# Altium schematic sheet COLLAUDO1.SchDoc
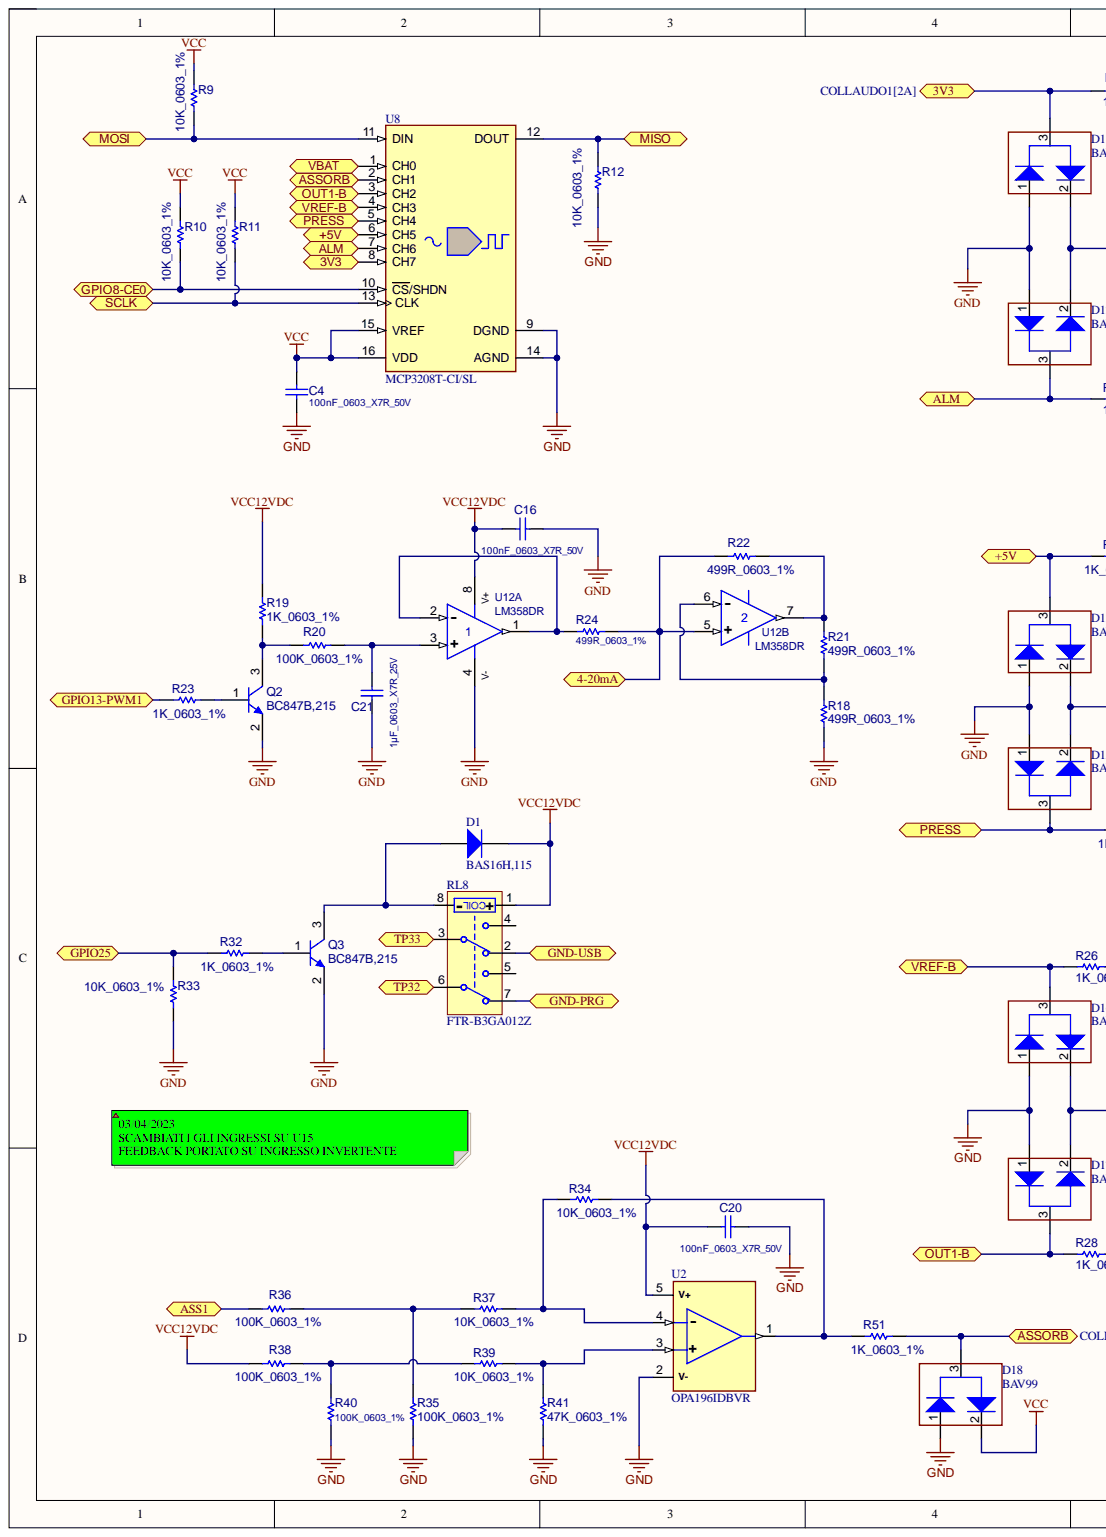
[diagram p0: COLLAUDO1.SchDoc, left]
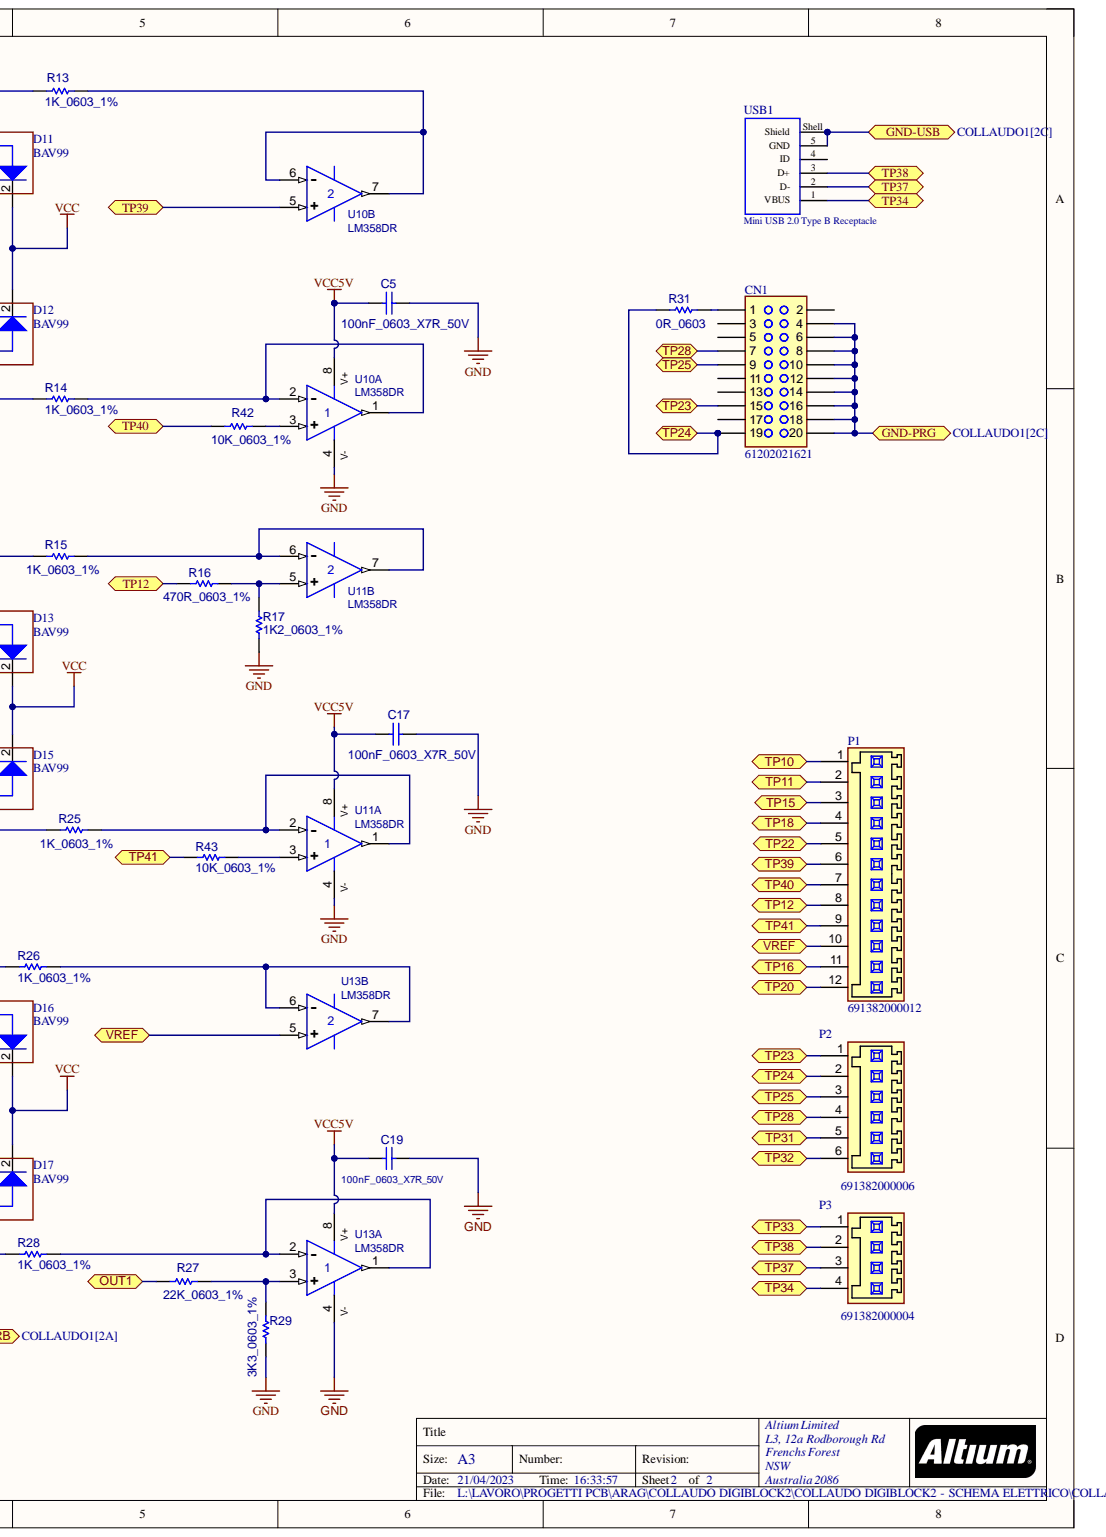
[diagram p1: COLLAUDO1.SchDoc, right]

## Parts
C4  100nF_0603_X7R_50V  [C_0603]
C5  100nF_0603_X7R_50V  [C_0603]
C16  100nF_0603_X7R_50V  [C_0603]
C17  100nF_0603_X7R_50V  [C_0603]
C19  100nF_0603_X7R_50V  [C_0603]
C20  100nF_0603_X7R_50V  [C_0603]
C21  1µF_0603_X7R_25V  [C_0603]
CN1  61202021621  [CONNETTORE_WE_61202021621]
D1  BAS16H,115  [SOD_123FL]
D11  BAV99  [SOT-23 3PIN]
D12  BAV99  [SOT-23 3PIN]
D13  BAV99  [SOT-23 3PIN]
D15  BAV99  [SOT-23 3PIN]
D16  BAV99  [SOT-23 3PIN]
D17  BAV99  [SOT-23 3PIN]
D18  BAV99  [SOT-23 3PIN]
P1  691382000012  [CONNETTORE_WE_691382000012]
P2  691382000006  [CONNETTORE_WE_691382000006]
P3  691382000004  [CONNETTORE_WE_691382000004]
Q2  BC847B,215  [SOT-23 3PIN]
Q3  BC847B,215  [SOT-23 3PIN]
R9  10K_0603_1%  [R_0603]
R10  10K_0603_1%  [R_0603]
R11  10K_0603_1%  [R_0603]
R12  10K_0603_1%  [R_0603]
R13  1K_0603_1%  [R_0603]
R14  1K_0603_1%  [R_0603]
R15  1K_0603_1%  [R_0603]
R16  470R_0603_1%  [R_0603]
R17  1K2_0603_1%  [R_0603]
R18  499R_0603_1%  [R_0603]
R19  1K_0603_1%  [R_0603]
R20  100K_0603_1%  [R_0603]
R21  499R_0603_1%  [R_0603]
R22  499R_0603_1%  [R_0603]
R23  1K_0603_1%  [R_0603]
R24  499R_0603_1%  [R_0603]
R25  1K_0603_1%  [R_0603]
R26  1K_0603_1%  [R_0603]
R27  22K_0603_1%  [R_0603]
R28  1K_0603_1%  [R_0603]
R29  3K3_0603_1%  [R_0603]
R31  0R_0603  [R_0603]
R32  1K_0603_1%  [R_0603]
R33  10K_0603_1%  [R_0603]
R34  10K_0603_1%  [R_0603]
R35  100K_0603_1%  [R_0603]
R36  100K_0603_1%  [R_0603]
R37  10K_0603_1%  [R_0603]
R38  100K_0603_1%  [R_0603]
R39  10K_0603_1%  [R_0603]
R40  100K_0603_1%  [R_0603]
R41  47K_0603_1%  [R_0603]
R42  10K_0603_1%  [R_0603]
R43  10K_0603_1%  [R_0603]
R51  1K_0603_1%  [R_0603]
RL8  FTR-B3GA012Z  [RELE' FUJITSU FTR-B3S]
U2  OPA196IDBVR  [SOT23_5PIN_TI]
U8  MCP3208T-CI/SL  [SOT23_5PIN]
U10  LM358DR  [SOP_8]  (parts A,B)
U11  LM358DR  [SOP_8]  (parts A,B)
U12  LM358DR  [SOP_8]  (parts A,B)
U13  LM358DR  [SOP_8]  (parts A,B)
USB1  Mini USB 2.0 Type B Receptacle  Application: Mini USB 2.0  Gender: Receptacle  Interface Type: Type B  [614105150721]

## Ports
3V3, 4-20mA, +5V, ALM, ASS1, ASSORB, GND-PRG, GND-USB, GPIO8-CE0, GPIO13-PWM1, GPIO25, MISO, MOSI, OUT1, OUT1-B, PRESS, SCLK, TP10, TP11, TP12, TP15, TP16, TP18, TP20, TP22, TP23, TP24, TP25, TP28, TP31, TP32, TP33, TP34, TP37, TP38, TP39, TP40, TP41, VBAT, VREF, VREF-B

## Nets
GND: C4.1 C5.2 C16.2 C17.2 C19.2 C20.2 C21.1 D11.1 D12.1 D13.1 D15.1 D16.1 D17.1 D18.1 Q2.2 Q3.2 R12.2 R17.2 R18.1 R29.1 R33.2 R35.1 R40.1 R41.2 U2.2(V-) U8.9(DGND) U8.14(AGND) U10.4 U11.4 U12.4 U13.4
VCC: C4.2 D11.2 D12.2 D13.2 D15.2 D16.2 D17.2 D18.2 R9.1 R10.1 R11.1 U8.15(VREF) U8.16(VDD)
VCC5V: C5.1 C17.1 C19.1 U10.8 U11.8 U13.8
VCC12VDC: C16.1 C20.1 D1.2 R19.2 R38.1 RL8.1(+) U2.5(V+) U12.8
3V3: D11.3 R13.1 U8.8(CH7) ; port 3V3
4-20mA: R22.1 R24.1 U12.5(+) ; port 4-20mA
+5V: D13.3 R15.1 U8.6(CH5) ; port +5V
ALM: D12.3 R14.1 U8.7(CH6) ; port ALM
ASS1: R36.1 ; port ASS1
ASSORB: D18.3 R51.2 U8.2(CH1) ; port ASSORB
GND-PRG: CN1.4 CN1.6 CN1.8 CN1.10 CN1.12 CN1.14 CN1.16 CN1.18 CN1.20 RL8.7 ; port GND-PRG
GND-USB: RL8.2 USB1.5(GND) USB1.Shell(Shield) ; port GND-USB
GPIO8-CE0: R10.2 U8.10(CS/SHDN) ; port GPIO8-CE0
GPIO13-PWM1: R23.1 ; port GPIO13-PWM1
GPIO25: R32.1 R33.1 ; port GPIO25
MISO: R12.1 U8.12(DOUT) ; port MISO
MOSI: R9.2 U8.11(DIN) ; port MOSI
OUT1: R27.1 ; port OUT1
OUT1-B: D17.3 R28.1 U8.3(CH2) ; port OUT1-B
PRESS: D15.3 R25.1 U8.5(CH4) ; port PRESS
SCLK: R11.2 U8.13(CLK) ; port SCLK
TP10: P1.1 ; port TP10
TP11: P1.2 ; port TP11
TP12: P1.8 R16.2 ; port TP12
TP15: P1.3 ; port TP15
TP16: P1.11 ; port TP16
TP18: P1.4 ; port TP18
TP20: P1.12 ; port TP20
TP22: P1.5 ; port TP22
TP23: CN1.15 P2.1 ; port TP23
TP24: CN1.19 P2.2 R31.1 ; port TP24
TP25: CN1.9 P2.3 ; port TP25
TP28: CN1.7 P2.4 ; port TP28
TP31: P2.5 ; port TP31
TP32: P2.6 RL8.6 ; port TP32
TP33: P3.1 RL8.3 ; port TP33
TP34: P3.4 USB1.1(VBUS) ; port TP34
TP37: P3.3 USB1.2(D-) ; port TP37
TP38: P3.2 USB1.3(D+) ; port TP38
TP39: P1.6 U10.5(+) ; port TP39
TP40: P1.7 R42.2 ; port TP40
TP41: P1.9 R43.1 ; port TP41
VBAT: U8.1(CH0) ; port VBAT
VREF: P1.10 U13.5(+) ; port VREF
VREF-B: D16.3 R26.1 U8.4(CH3) ; port VREF-B
NetC21_2: C21.2 R20.2 U12.3(+)
NetCN1_1: CN1.1 R31.2
NetD1_1: D1.1 Q3.3 RL8.8(-)
NetQ2_1: Q2.1 R23.2
NetQ2_3: Q2.3 R19.1 R20.1
NetQ3_1: Q3.1 R32.2
NetR13_2: R13.2 U10.6(-) U10.7
NetR14_2: R14.2 U10.1 U10.2(-)
NetR15_2: R15.2 U11.6(-) U11.7
NetR16_1: R16.1 R17.1 U11.5(+)
NetR18_2: R18.2 R21.1 U12.6(-)
NetR21_2: R21.2 R22.2 U12.7
NetR24_2: R24.2 U12.1 U12.2(-)
NetR25_2: R25.2 U11.1 U11.2(-)
NetR26_2: R26.2 U13.6(-) U13.7
NetR27_2: R27.2 R29.2 U13.3(+)
NetR28_2: R28.2 U13.1 U13.2(-)
NetR34_1: R34.1 R51.1 U2.1
NetR34_2: R34.2 R37.1 U2.4(-)
NetR35_2: R35.2 R36.2 R37.2
NetR38_2: R38.2 R39.2 R40.2
NetR39_1: R39.1 R41.1 U2.3(+)
NetR42_1: R42.1 U10.3(+)
NetR43_2: R43.2 U11.3(+)

Not connected: CN1 2 3 5 11 13 17; RL8 4 5; USB1 4(ID)

## Library data of the parts
C4 C5 C16 C17 C19 C20 = 100nF_0603_X7R_50V: CERAMIC CAPACITOR ±15% (-55° +125°) 50V -  0603; Manufacturer_Name: GENERICO; Codice_Magazzino: C0603-100N-X7R-50V; MOUNTING_TECHNOLOGY: SMD; Component Kind: Standard; Library Name: LIBRERIA STARTB4 COMPONENTI PASSIVI.SchLib
C21 = 1µF_0603_X7R_25V: CERAMIC CAPACITOR ±15% (-55° +125°) 25V -  0603; Manufacturer_Name: GENERICO; Codice_Magazzino: C0603-1U-X7R-25V; MOUNTING_TECHNOLOGY: SMD; Component Kind: Standard; Library Name: LIBRERIA STARTB4 COMPONENTI PASSIVI.SchLib
CN1 = 61202021621: CONNETTORE RIBBON CABLE 20 PIN P 2.54mm - VERTICALE; Manufacturer_Name: WE; MOUNTING_TECHNOLOGY: THT; Codice_Magazzino: 61202021621; Component Kind: Standard; Library Name: LIBRERIA STARTB4 COMPONENTI ELETTROMECCANICI.SchLib
D1 = BAS16H,115: High-speed switching diode 100V 215mA SOD123F; Manufacturer_Name: NXP; MOUNTING_TECHNOLOGY: SMD; Codice_Magazzino: BAS16H,115; Component Kind: Standard; Library Name: LIBRERIA STARTB4 COMPONENTI ATTIVI.SchLib
D11-D13 D15-D18 = BAV99: DIODE ARRAY GP 100V 215MA SOT23; Manufacturer_Name: NXP; MOUNTING_TECHNOLOGY: SMD; Codice_Magazzino: BAV99; Component Kind: Standard; Library Name: LIBRERIA STARTB4 COMPONENTI ATTIVI.SchLib
P1 = 691382000012: WR-TBL Serie 382 Vertical PCB Header, Pluggable, THT, pitch 2.5mm, 12p; Manufacturer_Name: WE; Codice_Magazzino: 691382000012; Component Kind: Standard; MOUNTING_TECHNOLOGY: THT; Library Name: LIBRERIA STARTB4 COMPONENTI ELETTROMECCANICI.SchLib
P2 = 691382000006: WR-TBL Serie 382 Vertical PCB Header, Pluggable, THT, pitch 2.5mm, 6p; Manufacturer_Name: WE; Codice_Magazzino: 691382000006; Component Kind: Standard; MOUNTING_TECHNOLOGY: THT; Library Name: LIBRERIA STARTB4 COMPONENTI ELETTROMECCANICI.SchLib
P3 = 691382000004: WR-TBL Serie 382 Vertical PCB Header, Pluggable, THT, pitch 2.5mm, 4p; Manufacturer_Name: WE; Codice_Magazzino: 691382000004; Component Kind: Standard; MOUNTING_TECHNOLOGY: THT; Library Name: LIBRERIA STARTB4 COMPONENTI ELETTROMECCANICI.SchLib
Q2 Q3 = BC847B,215: General Purpose transistor,  NPN 45 V 100 mA 100MHz 250 mW, 3-Pin SOT-23; Manufacturer_Name: NXP; MOUNTING_TECHNOLOGY: SMD; Codice_Magazzino: BC847B,215; Component Kind: Standard; Library Name: LIBRERIA STARTB4 COMPONENTI ATTIVI.SchLib
R9-R12 R33 R34 R37 R39 R42 R43 = 10K_0603_1%: RESISTOR  0,1W, 1/10W ±1% 0603; Manufacturer_Name: GENERICO; Codice_Magazzino: R0603-10K-F; MOUNTING_TECHNOLOGY: SMD; Component Kind: Standard; Library Name: LIBRERIA STARTB4 COMPONENTI PASSIVI.SchLib
R13-R15 R19 R23 R25 R26 R28 R32 R51 = 1K_0603_1%: RESISTOR  0,1W, 1/10W ±1% 0603; Manufacturer_Name: GENERICO; Codice_Magazzino: R0603-1K-F; MOUNTING_TECHNOLOGY: SMD; Component Kind: Standard; Library Name: LIBRERIA STARTB4 COMPONENTI PASSIVI.SchLib
R16 = 470R_0603_1%: RESISTOR  0,1W, 1/10W ±1% 0603; Manufacturer_Name: GENERICO; Codice_Magazzino: R0603-470R-F; MOUNTING_TECHNOLOGY: SMD; Component Kind: Standard; Library Name: LIBRERIA STARTB4 COMPONENTI PASSIVI.SchLib
R17 = 1K2_0603_1%: RESISTOR  0,1W, 1/10W ±1% 0603; Manufacturer_Name: GENERICO; Codice_Magazzino: R0603-1K2-F; MOUNTING_TECHNOLOGY: SMD; Component Kind: Standard; Library Name: LIBRERIA STARTB4 COMPONENTI PASSIVI.SchLib
R18 R21 R22 R24 = 499R_0603_1%: RESISTOR  0,1W, 1/10W ±1% 0603; Manufacturer_Name: GENERICO; Codice_Magazzino: R0603-499R-F; MOUNTING_TECHNOLOGY: SMD; Component Kind: Standard; Library Name: LIBRERIA STARTB4 COMPONENTI PASSIVI.SchLib
R20 R35 R36 R38 R40 = 100K_0603_1%: RESISTOR  0,1W, 1/10W ±1% 0603; Manufacturer_Name: GENERICO; Codice_Magazzino: R0603-100K-F; MOUNTING_TECHNOLOGY: SMD; Component Kind: Standard; Library Name: LIBRERIA STARTB4 COMPONENTI PASSIVI.SchLib
R27 = 22K_0603_1%: RESISTOR  0,1W, 1/10W ±1% 0603; Manufacturer_Name: GENERICO; Codice_Magazzino: R0603-22K-F; MOUNTING_TECHNOLOGY: SMD; Component Kind: Standard; Library Name: LIBRERIA STARTB4 COMPONENTI PASSIVI.SchLib
R29 = 3K3_0603_1%: RESISTOR  0,1W, 1/10W ±1% 0603; Manufacturer_Name: GENERICO; Codice_Magazzino: R0603-3K3-F; MOUNTING_TECHNOLOGY: SMD; Component Kind: Standard; Library Name: LIBRERIA STARTB4 COMPONENTI PASSIVI.SchLib
R31 = 0R_0603: RESISTOR  0,1W, 1/10W ±1% 0603; Manufacturer_Name: GENERICO; Codice_Magazzino: R0603-0R; MOUNTING_TECHNOLOGY: SMD; Component Kind: Standard; Library Name: LIBRERIA STARTB4 COMPONENTI PASSIVI.SchLib
R41 = 47K_0603_1%: RESISTOR  0,1W, 1/10W ±1% 0603; Manufacturer_Name: GENERICO; Codice_Magazzino: R0603-47K-F; MOUNTING_TECHNOLOGY: SMD; Component Kind: Standard; Library Name: LIBRERIA STARTB4 COMPONENTI PASSIVI.SchLib
RL8 = FTR-B3GA012Z: 2 POLES - 2A (Low Profile Signal Relay) (DPDT-NO, NC) - COIL 12V; Manufacturer_Name: FUJITSU; MOUNTING_TECHNOLOGY: SMD; Codice_Magazzino: FTR-B3GA012Z; Component Kind: Standard; Library Name: LIBRERIA STARTB4 COMPONENTI PASSIVI.SchLib
U2 = OPA196IDBVR: Operational Amplifier  Rail-to-Rai - Low-Power, Low Offset Voltage ±2.25 V to ±18 V, 4.5 V to 36 V  −40°C to 125°C - SOT23-5; Manufacturer_Name: TI; MOUNTING_TECHNOLOGY: SMD; Codice_Magazzino: OPA196IDBVR; Component Kind: Standard; Library Name: LIBRERIA STARTB4 COMPONENTI ATTIVI.SchLib
U8 = MCP3208T-CI/SL: 8-Channel 12-Bit A/D Converters with SPI Serial Interface - Supply Voltage 2.7V to 5.5V -  -40°C +85°C - SOIC-16; Manufacturer_Name: MICROCHIP; MOUNTING_TECHNOLOGY: SMD; Codice_Magazzino: MCP3208T-CI/SL; Component Kind: Standard; Library Name: LIBRERIA STARTB4 COMPONENTI ATTIVI.SchLib
U10-U13 = LM358DR: Operational Amplifier Dual General Purpose  - Bandwidth: 700kHz  - Supply Voltage Range: ± 1.5V to ± 16V -  0°C to +70°C - 8-SOIC; Manufacturer_Name: TI; MOUNTING_TECHNOLOGY: SMD; Codice_Magazzino: LM358DR; Component Kind: Standard; Library Name: LIBRERIA STARTB4 COMPONENTI ATTIVI.SchLib
USB1 = 614105150721: Mini USB 2.0 Type B, Receptacle, Vertical, THT; Manufacturer: Wurth Elektronik; Pin Number: 5; Rated Current: 1.8A; Working Voltage: 30VAC; Component Kind: Standard; ComponentLink1Description: Manufacturer; ComponentLink1URL: http://www.we-online.de; ComponentLink2Description: Datasheet; ComponentLink2URL: https://katalog.we-online.com/em/datasheet/614105150721.pdf; Library Name: WR-USB_USB 2.0.SchLib; Manufacturer Part Number: 614105150721; Mounting Technology: THT; Operating Temperature: -40 to +85degC; Packaging: Tape and Reel; Published Date: August 2020; Type: Vertical
